# Altium schematic sheet Connectors & LEDs.SchDoc("Connectors & LEDs")
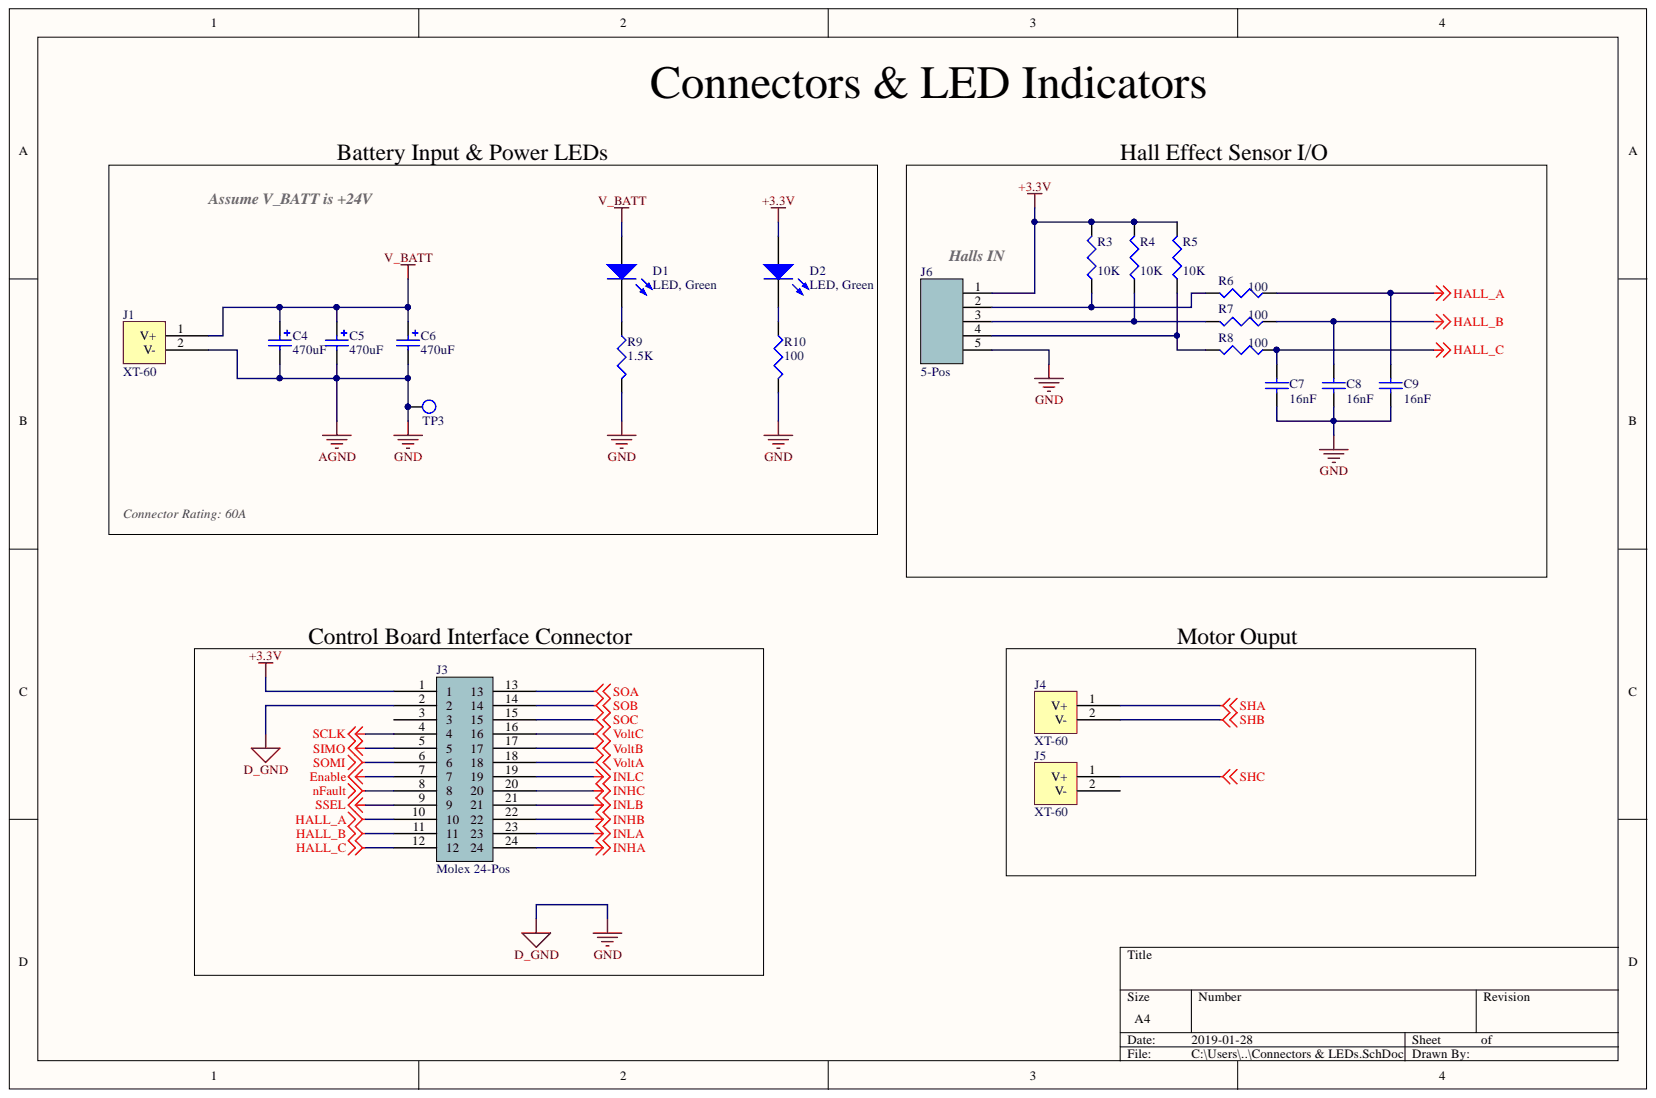
[diagram p0: Connectors & LEDs.SchDoc]

## Parts
C4  470uF  [ECA1JHG471]
C5  470uF  [ECA1JHG471]
C6  470uF  [ECA1JHG471]
C7  16nF  [0603 CAP Medium]
C8  16nF  [0603 CAP Medium]
C9  16nF  [0603 CAP Medium]
D1  LED, Green  [LED Green 0603]
D2  LED, Green  [LED Green 0603]
J1  XT-60  [XTC60PW-M]
J3  Molex 24-Pos  [PCBComponent_1]
J4  XT-60  [XTC60PW-M]
J5  XT-60  [XTC60PW-M]
J6  5-Pos  [Hirose 5-pos]
R3  value 10K  [RES 0603 MEDIUM]  (hidden comment: Res3)
R4  value 10K  [RES 0603 MEDIUM]  (hidden comment: Res3)
R5  value 10K  [RES 0603 MEDIUM]  (hidden comment: Res3)
R6  value 100  [RES 0603 MEDIUM]  (hidden comment: Res3)
R7  value 100  [RES 0603 MEDIUM]  (hidden comment: Res3)
R8  value 100  [RES 0603 MEDIUM]  (hidden comment: Res3)
R9  value 1.5K  [6-0805_N]  (hidden comment: Res3)
R10  value 100  [RES 0603 MEDIUM]  (hidden comment: Res3)
TP3  TP  [Test Point]

## Nets
+3.3V: D2.1 J3.1 J6.1 R3.2 R4.2 R5.2
AGND: C4.2 C5.2 C6.2 C7.1 C8.1 C9.1 J1.2 J3.2 J6.5 R9.2 R10.2 TP3.1
Enable: J3.7
HALL_A: C9.2 J3.10 R6.1
HALL_B: C8.2 J3.11 R7.1
HALL_C: C7.2 J3.12 R8.1
INHA: J3.24
INHB: J3.22
INHC: J3.20
INLA: J3.23
INLB: J3.21
INLC: J3.19
nFault: J3.8
SCLK: J3.4
SHA: J4.1
SHB: J4.2
SHC: J5.1
SIMO: J3.5
SOA: J3.13
SOB: J3.14
SOC: J3.15
SOMI: J3.6
SSEL: J3.9
V_BATT: C4.1 C5.1 C6.1 D1.1 J1.1
VoltA: J3.18
VoltB: J3.17
VoltC: J3.16
NetD1_2: D1.2 R9.1
NetD2_2: D2.2 R10.1
NetJ6_2: J6.2 R3.1 R6.2
NetJ6_3: J6.3 R4.1 R7.2
NetJ6_4: J6.4 R5.1 R8.2

Not connected: J3 3; J5 2

## Library data of the parts
C4-C6 = ECA-1JHG471: Cap Aluminum Lytic 470uF 63V 20% (12.5 X 20mm) Radial 5mm 640mA 2000h 105C Bulk; Manufacturer: Panasonic; Polarity: Polar; Life (Hours): 2000Hour; Leakage Current: 3uA; Lead Diameter: 0.6mm; Lead/Base Style: Straight; Dissipation Factor: 0.1%; Voltage Rating (DC): 63V; Voltage Rating: 63V; Voltage: 63V; Tolerance: 20%; Termination: Radial; Supplier 1: Digi-Key; Stock 1: 12555; Simulation: Model Name; Signal Integrity: Model Name; Ripple Current: 640mA; REACH SVHC: No SVHC; Radiation Hardening: No; Pricing 1: 1=0.88, 10=0.67, 100=0.4792, 500=0.371, 1000=0.31948, 2500=0.29887, 5000=0.28341 (USD); Packaging: Bulk; Package Quantity: 200; Mount: Through Hole,PCB; Min Operating Temperature: -55°C; Max Operating Temperature: 105°C; Manufacturer Part Number: ECA-1JHG471; Length: 20mm; Lead Pitch: 5mm; Height - Seated (Max): 0.866inch; Height: 20mm; Diameter: 12.5mm; Contact Plating: Tin; ComponentLink7URL: https://datasheet.ciiva.com/43485/1504317-43485276.pdf; ComponentLink7Description: https://datasheet.ciiva.com/43485/1504317-43485276.pdf; ComponentLink6URL: https://datasheet.ciiva.com/11783/0900766b800301b8-11783820.pdf; ComponentLink6Description: https://datasheet.ciiva.com/11783/0900766b800301b8-11783820.pdf; ComponentLink5URL: https://datasheet.ciiva.com/2984/aba0000ce26-2984364.pdf; ComponentLink5Description: https://datasheet.ciiva.com/2984/aba0000ce26-2984364.pdf; ComponentLink4URL: https://datasheet.ciiva.com/30116/8aba0000ce26-30116426.pdf; ComponentLink4Description: https://datasheet.ciiva.com/30116/8aba0000ce26-30116426.pdf; ComponentLink3URL: https://datasheet.ciiva.com/48521/radial-nhg-eng-tds-48521993.pdf; ComponentLink3Description: https://datasheet.ciiva.com/48521/radial-nhg-eng-tds-48521993.pdf; ComponentLink2URL: https://datasheet.ciiva.com/17356/aba0000ce26-17356313.pdf; ComponentLink2Description: https://datasheet.ciiva.com/17356/aba0000ce26-17356313.pdf; ComponentLink1URL: https://datasheet.ciiva.com/14590/70067776-14590006.pdf; ComponentLink1Description: https://datasheet.ciiva.com/14590/70067776-14590006.pdf; Component Kind: Standard; Case/Package: Radial; Capacitance: 470uF; Library Name: ECAR_LIBARY.SchLib
C7-C9 = 06035C163KAT2A: Multilayer Ceramic Capacitors MLCC - SMD/SMT 50volts 0.016uF 10% X7R; Manufacturer: Kyocera AVX; Voltage Rating (DC): 50V; Dissipation Factor: 2.5%; Width: 0.032inch; Voltage Rating: 50V; Tolerance: 10%; Thickness: 0.035inch; Termination: SMD/SMT; Supplier 2: Digi-Key; Supplier 1: Digi-Key; Stock 1: 10610; Signal Integrity: Model Name; Radiation Hardening: No; Pricing 1: 1=0.33, 10=0.224, 100=0.1271, 500=0.0897, 1000=0.07849 (USD); Packaging: Tape and Reel; Package Quantity: 4000; Number of Pins: 2; Mount: Surface Mount; Min Operating Temperature: -55°C; Max Operating Temperature: 125°C; Manufacturer Part Number: 06035C163KAT2A; Length: 1.6mm; Dielectric: X7R; Depth: 0.81mm; ComponentLink2URL: https://datasheet.ciiva.com/5418/cx7r-218113-5418475.pdf; ComponentLink2Description: https://datasheet.ciiva.com/5418/cx7r-218113-5418475.pdf; ComponentLink1URL: https://datasheet.ciiva.com/205/cx7r-205833.pdf; ComponentLink1Description: https://datasheet.ciiva.com/205/cx7r-205833.pdf; Component Kind: Standard; Case Code (Metric): 1608; Case Code (Imperial): 0603; Case/Package: 0603; Capacitance: 16nF; Library Name: ECAR_LIBARY.SchLib
D1 D2 = LED2: Typical RED, GREEN, YELLOW, AMBER GaAs LED; Simulation: LED2; Published: 8-Jun-2000; PackageVersion: Feb-2002; PackageReference: 3.2X1.6X1.1; PackageDescription: SM LED; 2 Flat Leads; Library Name: Miscellaneous Devices.IntLib; LatestRevisionNote: Re-released for DXP Platform.; LatestRevisionDate: 17-Jul-2002; ComponentLink1URL: https://www.digikey.ca/product-detail/en/rohm-semiconductor/SML-D12M8WT86/511-1578-1-ND/1641810; ComponentLink1Description: LED (green); Component Kind: Standard; Publisher: Altium Limited
J1 J4 J5 = XT-60: XT-60 Power Connector; Current_Max: 60; Simulation: Battery; ComponentLink1URL: https://cdn.sparkfun.com/datasheets/Prototyping/xt60.pdf; ComponentLink1Description: Mechanical; Component Kind: Standard; Library Name: ECAR_LIBARY.SchLib
J3 = Molex 24-Pos (0039301240): Library Name: MOSFET Board Schematic Library.SchLib; Component Kind: Standard
J6 = 5 pos: Library Name: MOSFET Board Schematic Library.SchLib; ComponentLink1URL: https://www.digikey.ca/product-detail/en/hirose-electric-co-ltd/DF3A-5P-2DSA/H2097-ND/141521; ComponentLink1Description: 5-pos Hirose; Component Kind: Standard
R3-R10 = Res3: Resistor; Simulation: RESISTOR; Signal Integrity: Res; Published: 8-Jun-2000; PackageReference: J1-0603; PackageDescription: Chip Resistor; Library Name: Miscellaneous Devices.IntLib; LatestRevisionNote: IPC-7351 Footprint Added.; LatestRevisionDate: 29-May-2009; Component Kind: Standard; Publisher: Altium Limited
  R3-R5: ComponentLink1URL: https://www.digikey.ca/product-detail/en/vishay-dale/CRCW060310K0FKEAHP/541-10.0KSCT-ND/2222780; ComponentLink1Description: 10KOhm
  R6-R8 R10: ComponentLink1URL: https://www.digikey.ca/product-detail/en/vishay-dale/CRCW0603100RFKEA/541-100HCT-ND/1179695; ComponentLink1Description: 100Ohm
  R9: ComponentLink1URL: https://www.digikey.ca/product-detail/en/rohm-semiconductor/ESR10EZPJ152/RHM1.5KKCT-ND/1762968; ComponentLink1Description: 1.5KOhm
TP3 = Test Point (5029): Keystone Electronics PC Test Point, Miniature Phosphor Bronze, Silver Plating Surface Mount Mounting Type; Library Name: MOSFET Board Schematic Library.SchLib; ComponentLink1URL: https://www.digikey.ca/product-detail/en/keystone-electronics/5029/36-5029CT-ND/5980631; ComponentLink1Description: Test Point; Component Kind: Standard
